# Altium schematic sheet [01] - POWER.SchDoc("[01] - POWER")
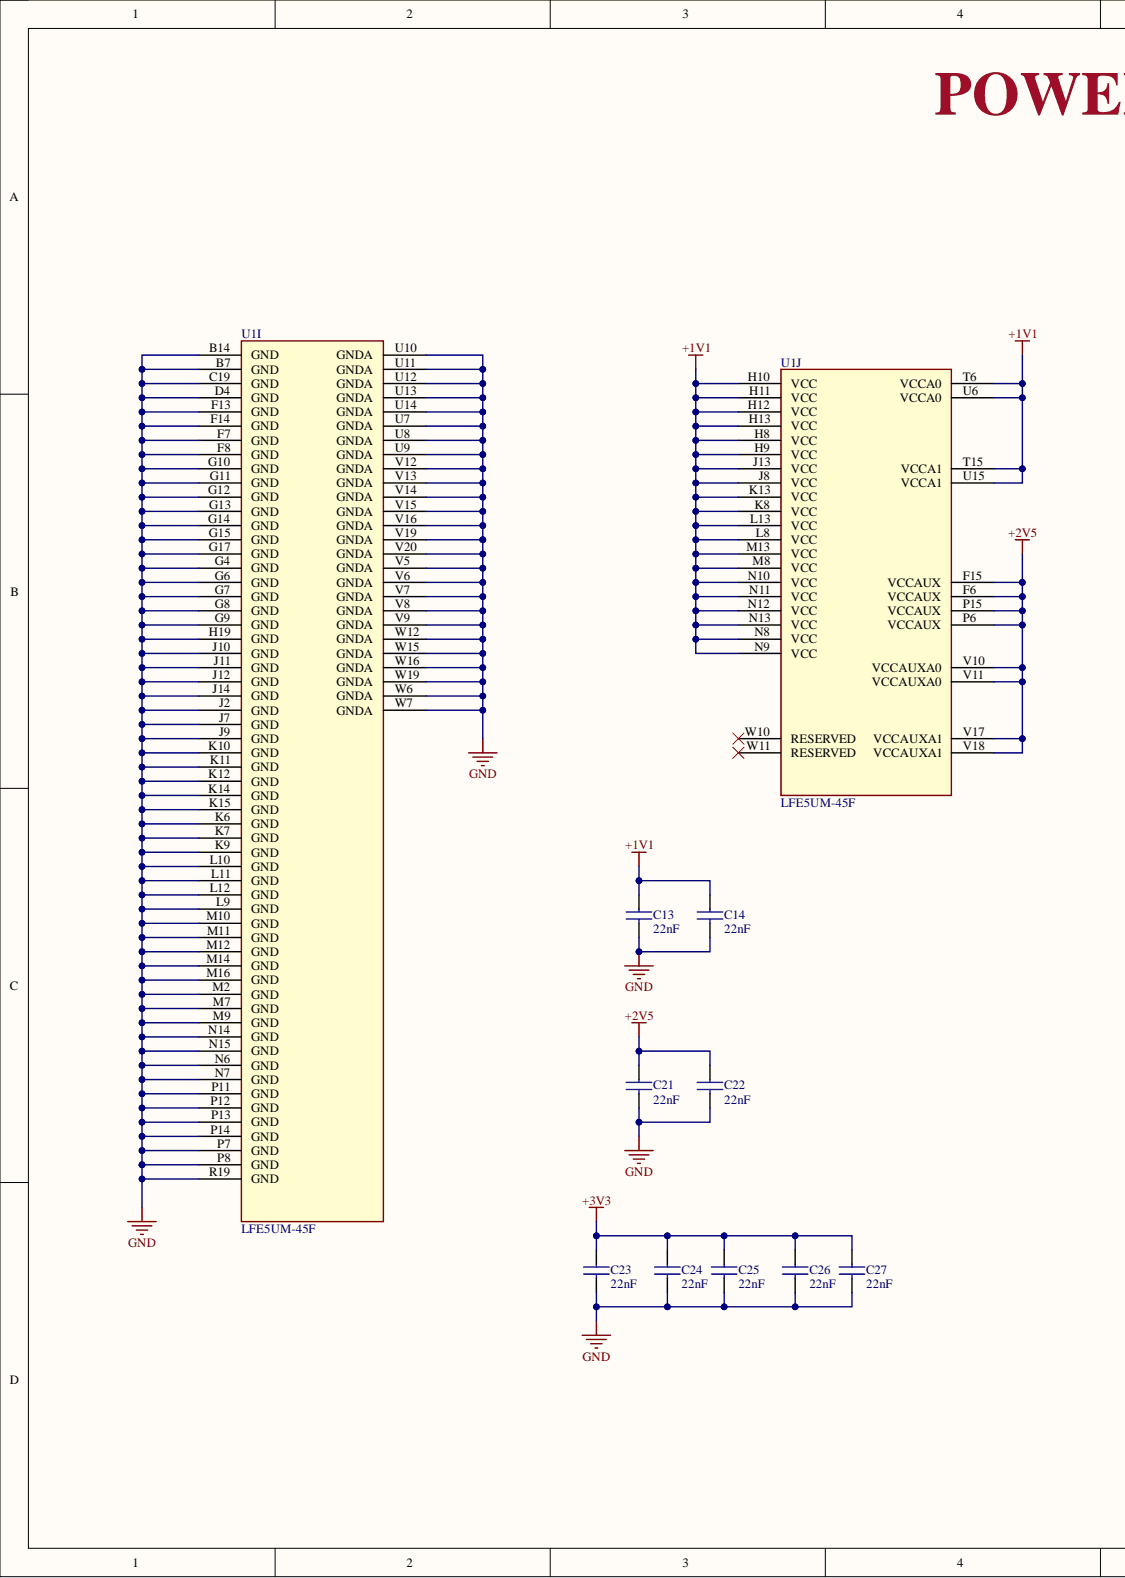
[diagram p0: [01] - POWER.SchDoc, left]
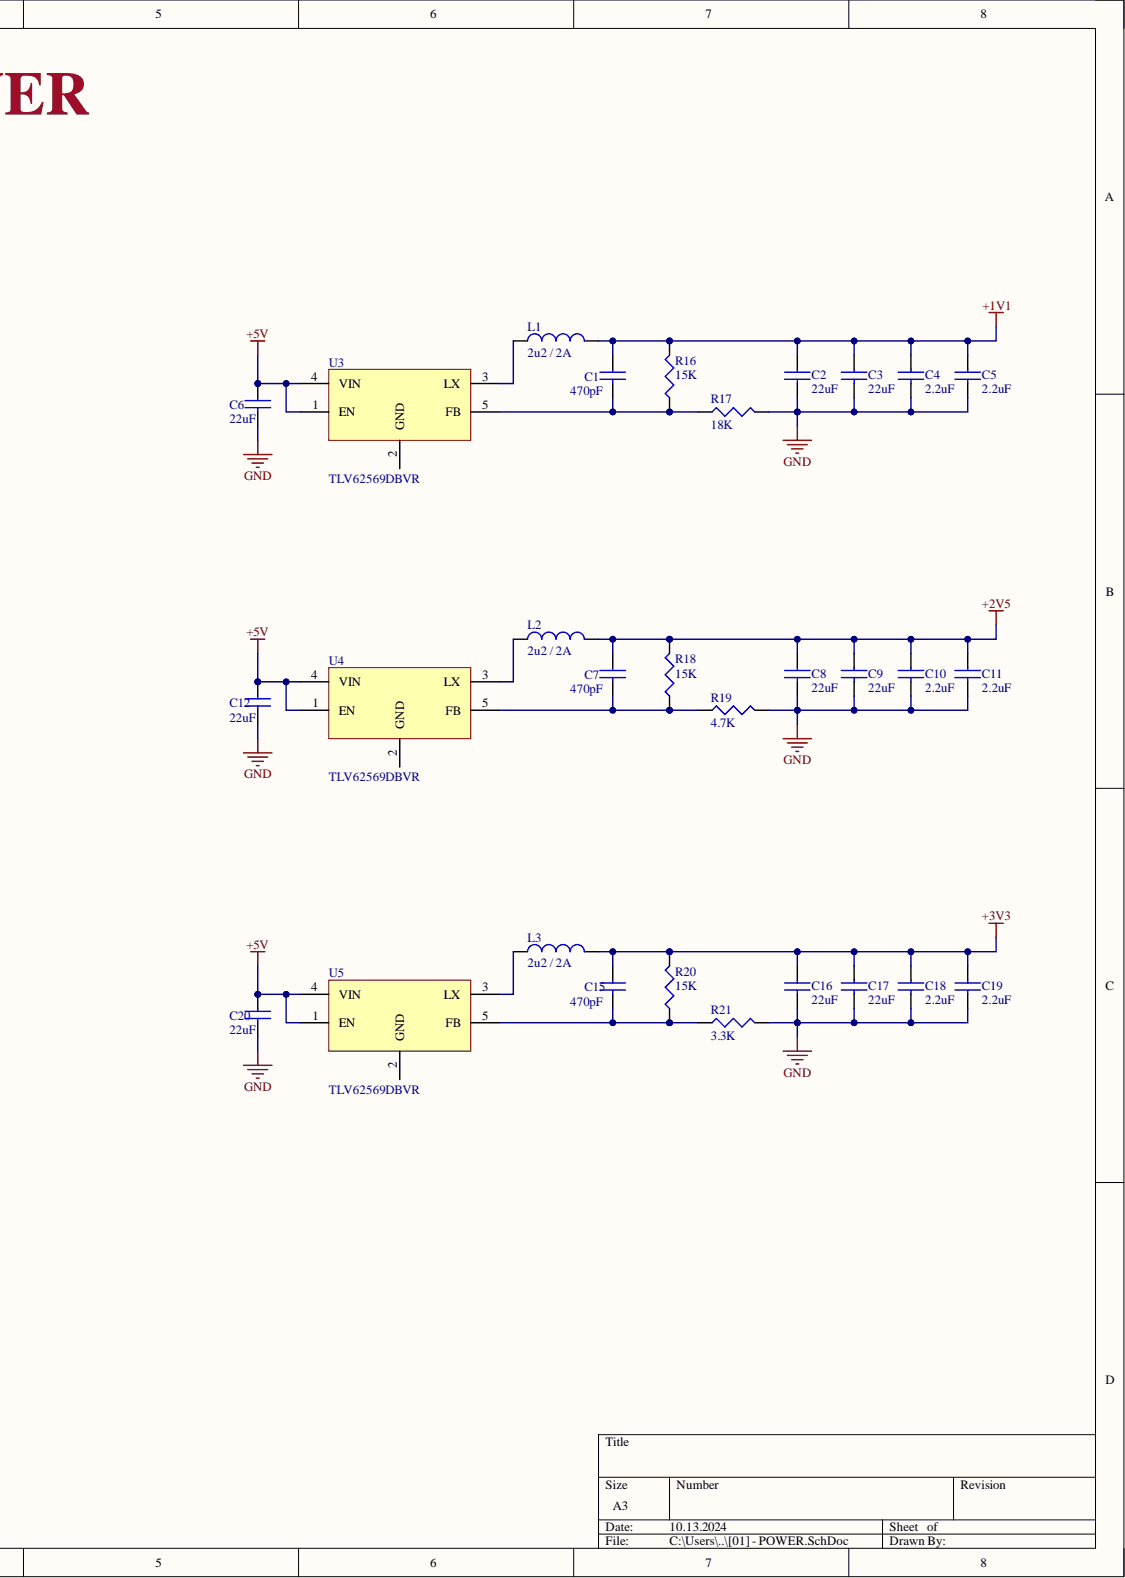
[diagram p1: [01] - POWER.SchDoc, right]

## Parts
C1  470pF  [C0603]
C2  22uF  [C0805]
C3  22uF  [C0805]
C4  2.2uF  [C0805]
C5  2.2uF  [C0805]
C6  22uF  [C0805]
C7  470pF  [C0603]
C8  22uF  [C0805]
C9  22uF  [C0805]
C10  2.2uF  [C0805]
C11  2.2uF  [C0805]
C12  22uF  [C0805]
C13  22nF  [C0603]
C14  22nF  [C0603]
C15  470pF  [C0603]
C16  22uF  [C0805]
C17  22uF  [C0805]
C18  2.2uF  [C0805]
C19  2.2uF  [C0805]
C20  22uF  [C0805]
C21  22nF  [C0603]
C22  22nF  [C0603]
C23  22nF  [C0603]
C24  22nF  [C0603]
C25  22nF  [C0603]
C26  22nF  [C0603]
C27  22nF  [C0603]
L1  2u2 / 2A  Current Rating: 2A  [C1210_IND]
L2  2u2 / 2A  Current Rating: 2A  [C1210_IND]
L3  2u2 / 2A  Current Rating: 2A  [C1210_IND]
R16  15K  [R0603]
R17  18K  [R0603]
R18  15K  [R0603]
R19  4.7K  [R0603]
R20  15K  [R0603]
R21  3.3K  [R0603]
U1  LFE5UM-45F  [LFE5BG381]  (parts I,J)
U3  TLV62569DBVR  [SOT95P370X145-5N]
U4  TLV62569DBVR  [SOT95P370X145-5N]
U5  TLV62569DBVR  [SOT95P370X145-5N]

## Nets
+1V1: C1.1 C2.1 C3.1 C4.1 C5.1 C13.1 C14.1 L1.2 R16.2 U1.H8(VCC) U1.H9(VCC) U1.H10(VCC) U1.H11(VCC) U1.H12(VCC) U1.H13(VCC) U1.J8(VCC) U1.J13(VCC) U1.K8(VCC) U1.K13(VCC) U1.L8(VCC) U1.L13(VCC) U1.M8(VCC) U1.M13(VCC) U1.N8(VCC) U1.N9(VCC) U1.N10(VCC) U1.N11(VCC) U1.N12(VCC) U1.N13(VCC) U1.T6(VCCA0) U1.T15(VCCA1) U1.U6(VCCA0) U1.U15(VCCA1)
+2V5: C7.1 C8.1 C9.1 C10.1 C11.1 C21.1 C22.1 L2.2 R18.2 U1.F6(VCCAUX) U1.F15(VCCAUX) U1.P6(VCCAUX) U1.P15(VCCAUX) U1.V10(VCCAUXA0) U1.V11(VCCAUXA0) U1.V17(VCCAUXA1) U1.V18(VCCAUXA1)
+3V3: C15.1 C16.1 C17.1 C18.1 C19.1 C23.1 C24.1 C25.1 C26.1 C27.1 L3.2 R20.2
+5V: C6.1 C12.1 C20.1 U3.1(EN) U3.4(VIN) U4.1(EN) U4.4(VIN) U5.1(EN) U5.4(VIN)
GND: C2.2 C3.2 C4.2 C5.2 C6.2 C8.2 C9.2 C10.2 C11.2 C12.2 C13.2 C14.2 C16.2 C17.2 C18.2 C19.2 C20.2 C21.2 C22.2 C23.2 C24.2 C25.2 C26.2 C27.2 R17.2 R19.2 R21.2 U1.B7(GND) U1.B14(GND) U1.C19(GND) U1.D4(GND) U1.F7(GND) U1.F8(GND) U1.F13(GND) U1.F14(GND) U1.G4(GND) U1.G6(GND) U1.G7(GND) U1.G8(GND) U1.G9(GND) U1.G10(GND) U1.G11(GND) U1.G12(GND) U1.G13(GND) U1.G14(GND) U1.G15(GND) U1.G17(GND) U1.H19(GND) U1.J2(GND) U1.J7(GND) U1.J9(GND) U1.J10(GND) U1.J11(GND) U1.J12(GND) U1.J14(GND) U1.K6(GND) U1.K7(GND) U1.K9(GND) U1.K10(GND) U1.K11(GND) U1.K12(GND) U1.K14(GND) U1.K15(GND) U1.L9(GND) U1.L10(GND) U1.L11(GND) U1.L12(GND) U1.M2(GND) U1.M7(GND) U1.M9(GND) U1.M10(GND) U1.M11(GND) U1.M12(GND) U1.M14(GND) U1.M16(GND) U1.N6(GND) U1.N7(GND) U1.N14(GND) U1.N15(GND) U1.P7(GND) U1.P8(GND) U1.P11(GND) U1.P12(GND) U1.P13(GND) U1.P14(GND) U1.R19(GND) U1.U7(GNDA) U1.U8(GNDA) U1.U9(GNDA) U1.U10(GNDA) U1.U11(GNDA) U1.U12(GNDA) U1.U13(GNDA) U1.U14(GNDA) U1.V5(GNDA) U1.V6(GNDA) U1.V7(GNDA) U1.V8(GNDA) U1.V9(GNDA) U1.V12(GNDA) U1.V13(GNDA) U1.V14(GNDA) U1.V15(GNDA) U1.V16(GNDA) U1.V19(GNDA) U1.V20(GNDA) U1.W6(GNDA) U1.W7(GNDA) U1.W12(GNDA) U1.W15(GNDA) U1.W16(GNDA) U1.W19(GNDA)
NetC1_2: C1.2 R16.1 R17.1 U3.5(FB)
NetC7_2: C7.2 R18.1 R19.1 U4.5(FB)
NetC15_2: C15.2 R20.1 R21.1 U5.5(FB)
NetL1_1: L1.1 U3.3(LX)
NetL2_1: L2.1 U4.3(LX)
NetL3_1: L3.1 U5.3(LX)

Not connected: U1 W10(RESERVED) W11(RESERVED); U3 2(GND); U4 2(GND); U5 2(GND)

## Library data of the parts
C1 C7 C15 = C0603C471K3RACTU: KEMET - C0603C471K3RACTU - SMD Multilayer Ceramic Capacitor, 470 pF, 25 V, 0603 [1608 Metric], ± 10%, X7R, C Series KEMET; Voltage Rating: 25V; Capacitance: 470pF; Case Code (Imperial): 0603; Case Code (Metric): 1608; Case/Package: 0603; Component Kind: Standard; ComponentLink1Description: http://www.farnell.com/datasheets/2064971.pdf?src-supplier=Element14; ComponentLink1URL: http://www.farnell.com/datasheets/2064971.pdf?src-supplier=Element14; ComponentLink2Description: http://www.farnell.com/datasheets/2746078.pdf?src-supplier=Farnell; ComponentLink2URL: http://www.farnell.com/datasheets/2746078.pdf?src-supplier=Farnell; ComponentLink3Description: https://api.kemet.com/component-edge/download/datasheet/C0603C471K3RACTU.pdf?src-supplier=Digi-Key; ComponentLink3URL: https://api.kemet.com/component-edge/download/datasheet/C0603C471K3RACTU.pdf?src-supplier=Digi-Key; ComponentLink4Description: http://components.arrow.com/part/dataview/2089229S9467247N7429?src-supplier=Arrow; ComponentLink4URL: http://components.arrow.com/part/dataview/2089229S9467247N7429?src-supplier=Arrow; ComponentLink5Description: http://www.mouser.com/ds/2/212/KEM_C1002_X7R_SMD-1102033.pdf?src-supplier=Mouser; ComponentLink5URL: http://www.mouser.com/ds/2/212/KEM_C1002_X7R_SMD-1102033.pdf?src-supplier=Mouser; ComponentLink6Description: http://www.farnell.com/datasheets/2746078.pdf?src-supplier=Newark; ComponentLink6URL: http://www.farnell.com/datasheets/2746078.pdf?src-supplier=Newark; ComponentLink7Description: http://components.arrow.com/part/dataview/0323_00323242?src-supplier=Arrow+Europe; ComponentLink7URL: http://components.arrow.com/part/dataview/0323_00323242?src-supplier=Arrow+Europe; Depth: 0.8mm; Dielectric: X7R; Dielectric Material: Ceramic; Height: 0.8mm; Lead Pitch: 0.7mm; Length: 1.6mm; Library Name: ECP5_V2.SchLib; Manufacturer: KEMET; Manufacturer Part Number: C0603C471K3RACTU; Max Operating Temperature: 125°C; Min Operating Temperature: -55°C; Mount: Surface Mount; Package Quantity: 4000; Packaging: Tape and Reel; Pricing 1: 1=0.1, 10=0.067, 100=0.0298, 500=0.02126, 1000=0.0167 (USD); Radiation Hardening: No; REACH SVHC: No SVHC; Stock 1: 28906; Supplier 1: Digi-Key; Supplier Part Number 1: 399-9086-6-ND; Termination: SMD/SMT; Thickness: 0.034inch; Tolerance: 10%; Voltage Rating (DC): 25V; Width: 0.031inch
C2 C3 C6 C8 C9 C12 C16 C17 C20 = CL21A226MQCLRNC: CAP CER 22UF 6.3V X5R 0805; Manufacturer: Samsung; Tolerance: 20%; Capacitance: 22uF; Case Code (Imperial): 0805; Case Code (Metric): 2012; Case/Package: 0805; Component Kind: Standard; ComponentLink1Description: http://www.samsungsem.com/kr/support/product-search/mlcc/CL21A226MQCLRNC.jsp?src-supplier=Digi-Key; ComponentLink1URL: http://www.samsungsem.com/kr/support/product-search/mlcc/CL21A226MQCLRNC.jsp?src-supplier=Digi-Key; Depth: 1.25mm; Dielectric: X5R; Height: 0.85mm; Lead Free: Lead Free; Length: 2mm; Library Name: ECP5_V2.SchLib; Manufacturer Part Number: CL21A226MQCLRNC; Max Operating Temperature: 85°C; Min Operating Temperature: -55°C; Mount: Surface Mount; Package Quantity: 1; Packaging: Tape and Reel; Pricing 1: 1=0.36, 10=0.247, 100=0.1484, 500=0.11132, 1000=0.09401 (USD); Radiation Hardening: No; Stock 1: 271195; Supplier 1: Digi-Key; Supplier Part Number 1: 1276-2413-6-ND; Thickness: 0.037inch; Voltage Rating: 6.3V; Width: 0.049inch
C4 C5 C10 C11 C18 C19 = CL21A225KAFNNNG: Ceramic capacitor SMD 0805 2.2 µF 25 V 10 %; Manufacturer: Samsung; Tolerance: 10%; Voltage Rating: 25V; Capacitance: 2.2uF; Case Code (Imperial): 0805; Case Code (Metric): 2012; Case/Package: 0805; Component Kind: Standard; ComponentLink1Description: http://www.samsungsem.com/global/support/library/product-catalog/__icsFiles/afieldfile/2014/11/13/MLCC.pdf?src-supplier=Digi-Key; ComponentLink1URL: http://www.samsungsem.com/global/support/library/product-catalog/__icsFiles/afieldfile/2014/11/13/MLCC.pdf?src-supplier=Digi-Key; ComponentLink2Description: https://produktinfo.conrad.com/datenblaetter/450000-474999/455579-da-01-en-VS_KONDENSATOR_0805.pdf?src-supplier=Conrad; ComponentLink2URL: https://produktinfo.conrad.com/datenblaetter/450000-474999/455579-da-01-en-VS_KONDENSATOR_0805.pdf?src-supplier=Conrad; Length: 0.079inch; Library Name: ECP5_V2.SchLib; Manufacturer Part Number: CL21A225KAFNNNG; Material: Ceramic; Max Operating Temperature: 85°C; Min Operating Temperature: -55°C; Mount: Surface Mount; Package Quantity: 3000; Packaging: Tape and Reel; Pricing 1: 1=0.15, 10=0.11, 100=0.0517, 500=0.03626, 1000=0.03002 (USD); Stock 1: 303381; Supplier 1: Digi-Key; Supplier Part Number 1: 1276-6458-6-ND; Thickness: 0.053inch; Width: 0.049inch
C13 C14 C21-C27 = CX0603MRX7R8BB223: Feed Through Capacitors 22nF X7R 20% 25V; Manufacturer: Yageo; Capacitance: 22nF; Case Code (Imperial): 0603; Case Code (Metric): 1608; Case/Package: 0603; Component Kind: Standard; ComponentLink1Description: http://www.yageo.com/NewPortal/_en/search/productDocs.jsp?YageoPartNumber=CX0603MRX7R8BB223&src-supplier=Digi-Key; ComponentLink1URL: http://www.yageo.com/NewPortal/_en/search/productDocs.jsp?YageoPartNumber=CX0603MRX7R8BB223&src-supplier=Digi-Key; Dielectric: X7R; Height: 0.65mm; Lead Free: Lead Free; Length: 0.063inch; Library Name: ECP5_V2.SchLib; Manufacturer Part Number: CX0603MRX7R8BB223; Max Operating Temperature: 125°C; Min Operating Temperature: -55°C; Mount: Surface Mount; Number of Pins: 2; Package Quantity: 1; Packaging: Tape and Reel; Pricing 1: 1=0.92, 10=0.676, 100=0.4636, 500=0.36702, 1000=0.30907 (USD); REACH SVHC: No SVHC; Stock 1: 11069; Supplier 1: Digi-Key; Supplier Part Number 1: 311-1234-6-ND; Termination: SMD/SMT; Thickness: 0.03inch; Tolerance: 20%; Voltage Rating: 25V; Voltage Rating (DC): 25V; Width: 0.034inch
L1-L3 = L1210R2R2MDWIT: FIXED IND 2.2UH 2A 80 MOHM SMD; Manufacturer: KEMET; Core Material: Ferrite; DC Current: 1.13A; DC Resistance (DCR): 80mR; Inductance Tolerance: 20%; Self Resonant Frequency: 190MHz; Shielding: Unshielded; Test Frequency: 100kHz; Case Code (Imperial): 1210; Case Code (Metric): 3225; Case/Package: 1210; Component Kind: Standard; ComponentLink1Description: https://content.kemet.com/datasheets/F3115.pdf?src-supplier=Mouser; ComponentLink1URL: https://content.kemet.com/datasheets/F3115.pdf?src-supplier=Mouser; ComponentLink2Description: https://content.kemet.com/datasheets/F3115.pdf?src-supplier=Avnet+Europe; ComponentLink2URL: https://content.kemet.com/datasheets/F3115.pdf?src-supplier=Avnet+Europe; ComponentLink3Description: http://www.farnell.com/datasheets/27262.pdf?src-supplier=Element14; ComponentLink3URL: http://www.farnell.com/datasheets/27262.pdf?src-supplier=Element14; ComponentLink4Description: https://api.kemet.com/component-edge/download/datasheet/L1210R2R2MDWIT.pdf?src-supplier=Digi-Key; ComponentLink4URL: https://api.kemet.com/component-edge/download/datasheet/L1210R2R2MDWIT.pdf?src-supplier=Digi-Key; ComponentLink5Description: http://www.kemet.com/Lists/ProductCatalog/Attachments/57/F3115.pdf?src-supplier=Avnet; ComponentLink5URL: http://www.kemet.com/Lists/ProductCatalog/Attachments/57/F3115.pdf?src-supplier=Avnet; Composition: Wirewound,Ceramic; Depth: 2.7mm; Height: 2.5mm; Height - Seated (Max): 0.106inch; Inductance: 2.2uH; Length: 0.126inch; Library Name: ECP5_V2.SchLib; Manufacturer Part Number: L1210R2R2MDWIT; Max DC Current: 2A; Max Operating Temperature: 105°C; Military Standard: Not; Min Operating Temperature: -40°C; Mount: Surface Mount; Package Quantity: 1000; Packaging: Tape and Reel; Pricing 1: 1=0.55, 10=0.479, 100=0.3421, 500=0.28738 (USD); Radiation Hardening: No; REACH SVHC: No SVHC; Stock 1: 2998; Supplier 1: Digi-Key; Supplier Part Number 1: 399-9598-6-ND; Termination: SMD/SMT; Tolerance: 20%; Width: 0.098inch
R16 R18 R20 = RC0603FR-0715KL: Res Thick Film 0603 15K Ohm 1% 1/10W ?100ppm/?C Molded SMD Paper T/R; Manufacturer: Yageo; Max Power Dissipation: 100mW; Resistance: 15kR; Temperature Coefficient: 100ppm/°C; Voltage Rating: 50V; Case Code (Imperial): 0603; Case Code (Metric): 1608; Case/Package: 0603; Component Kind: Standard; ComponentLink1Description: http://www.yageo.com/documents/recent/PYu-RC_Group_51_RoHS_L_10.pdf?src-supplier=Digi-Key; ComponentLink1URL: http://www.yageo.com/documents/recent/PYu-RC_Group_51_RoHS_L_10.pdf?src-supplier=Digi-Key; ComponentLink2Description: http://www.yageo.com/documents/recent/PYu-RC_Group_51_RoHS_L_9.pdf?src-supplier=Digi-Key; ComponentLink2URL: http://www.yageo.com/documents/recent/PYu-RC_Group_51_RoHS_L_9.pdf?src-supplier=Digi-Key; ComponentLink3Description: https://www.verical.com/server-webapp/html/getDatasheet?partID=327895&src-supplier=Verical; ComponentLink3URL: https://www.verical.com/server-webapp/html/getDatasheet?partID=327895&src-supplier=Verical; Composition: Thick Film; Depth: 0.8mm; Features: Moisture Resistant; Height: 0.022inch; Lead Free: Lead Free; Length: 1.6mm; Library Name: ECP5_V2.SchLib; Manufacturer Part Number: RC0603FR-0715KL; Max Operating Temperature: 155°C; Military Standard: Not; Min Operating Temperature: -55°C; Number of Pins: 2; Number of Terminations: 2; Package Quantity: 1; Packaging: Tape and Reel; Power Rating: 100mW; Pricing 1: 1=0.1, 10=0.023, 100=0.0094, 1000=0.00421, 2500=0.00366 (USD); REACH SVHC: Unknown; Stock 1: 3342455; Supplier 1: Digi-Key; Supplier Part Number 1: 311-15.0KHRCT-ND; Termination: SMD/SMT; Tolerance: 1%; Voltage Rating (DC): 50V; Width: 0.031inch
R17 = RC0603FR-0718KL: YAGEO (PHYCOMP) - RC0603FR-0718KL - SMD Chip Resistor, 0603 [1608 Metric], 18 kohm, RC Series, 75 V, Thick Film, 100 mW; Power Rating: 100mW; Resistance: 18kR; Temperature Coefficient: 100ppm/°C; Tolerance: 1%; Voltage Rating: 75V; Voltage Rating (DC): 50V; Case Code (Imperial): 0603; Case Code (Metric): 1608; Case/Package: 0603; Component Kind: Standard; ComponentLink1Description: http://documents.rs-components.com/techlib://itc_doclist_GB.htm?stockno=5049959&src-supplier=RSComponents; ComponentLink1URL: http://documents.rs-components.com/techlib://itc_doclist_GB.htm?stockno=5049959&src-supplier=RSComponents; ComponentLink2Description: https://www.verical.com/server-webapp/html/getDatasheet?partID=325162&src-supplier=Verical; ComponentLink2URL: https://www.verical.com/server-webapp/html/getDatasheet?partID=325162&src-supplier=Verical; ComponentLink3Description: http://www.farnell.com/datasheets/2167052.pdf?src-supplier=Element14; ComponentLink3URL: http://www.farnell.com/datasheets/2167052.pdf?src-supplier=Element14; ComponentLink4Description: http://www.farnell.com/datasheets/1868787.pdf?src-supplier=Element14; ComponentLink4URL: http://www.farnell.com/datasheets/1868787.pdf?src-supplier=Element14; Composition: Thick Film; Depth: 0.8mm; Height: 0.45mm; Lead Free: Lead Free; Length: 1.6mm; Library Name: ECP5_V2.SchLib; Manufacturer: Yageo; Manufacturer Part Number: RC0603FR-0718KL; Max Operating Temperature: 155°C; Military Standard: Not; Min Operating Temperature: -55°C; Number of Pins: 2; Packaging: Tape and Reel; Pricing 1: 1=0.1, 10=0.023, 100=0.0094, 1000=0.00421, 2500=0.00366 (USD); REACH SVHC: Unknown; Stock 1: 0; Supplier 1: Digi-Key; Supplier Part Number 1: 311-18.0KHRDKR-ND; Termination: SMD/SMT; Weight: 7.1E-05oz; Width: 0.8mm
R19 = RC0603FR-074K7L: RES SMD 4.7K OHM 1% 1/10W 0603; Manufacturer: Yageo; Power Rating: 100mW; Resistance: 4.7kR; Temperature Coefficient: 100ppm/°C; Voltage Rating: 50V; Case Code (Imperial): 0603; Case Code (Metric): 1608; Case/Package: 0603; Component Kind: Standard; ComponentLink1Description: https://www.verical.com/server-webapp/html/getDatasheet?partID=422754&src-supplier=Verical; ComponentLink1URL: https://www.verical.com/server-webapp/html/getDatasheet?partID=422754&src-supplier=Verical; ComponentLink2Description: http://www.farnell.com/datasheets/2167052.pdf?src-supplier=Element14; ComponentLink2URL: http://www.farnell.com/datasheets/2167052.pdf?src-supplier=Element14; ComponentLink3Description: http://www.farnell.com/datasheets/38590.pdf?src-supplier=Element14; ComponentLink3URL: http://www.farnell.com/datasheets/38590.pdf?src-supplier=Element14; ComponentLink4Description: http://www.farnell.com/datasheets/1868787.pdf?src-supplier=Element14; ComponentLink4URL: http://www.farnell.com/datasheets/1868787.pdf?src-supplier=Element14; Composition: Thick Film; Depth: 0.8mm; Height: 0.45mm; Lead Free: Lead Free; Lead Pitch: 0.3mm; Length: 1.6mm; Library Name: ECP5_V2.SchLib; Manufacturer Part Number: RC0603FR-074K7L; Max Operating Temperature: 155°C; Military Standard: Not; Min Operating Temperature: -55°C; Number of Pins: 2; Packaging: Tape and Reel; Pricing 1: 1=0.1, 10=0.023, 100=0.0094, 1000=0.00421, 2500=0.00366 (USD); REACH SVHC: No SVHC; Stock 1: 1446473; Supplier 1: Digi-Key; Supplier Part Number 1: 311-4.70KHRCT-ND; Termination: SMD/SMT; Tolerance: 1%; Voltage Rating (DC): 50V; Width: 0.8mm
R21 = RC0603FR-073K3L: YAGEO - RC0603FR-073K3L - SMD Chip Resistor, 0603 [1608 Metric], 3.3 kohm, RC Series, 75 V, Thick Film, 100 mW; Max Power Dissipation: 100mW; Resistance: 3.3kR; Temperature Coefficient: 100ppm/°C; Tolerance: 1%; Voltage Rating: 50V; Case Code (Imperial): 0603; Case Code (Metric): 1608; Case/Package: 0603; Component Kind: Standard; ComponentLink1Description: http://www.yageo.com/documents/recent/PYu-RC_Group_51_RoHS_L_10.pdf?src-supplier=Digi-Key; ComponentLink1URL: http://www.yageo.com/documents/recent/PYu-RC_Group_51_RoHS_L_10.pdf?src-supplier=Digi-Key; ComponentLink2Description: http://www.farnell.com/datasheets/2167052.pdf?src-supplier=Element14; ComponentLink2URL: http://www.farnell.com/datasheets/2167052.pdf?src-supplier=Element14; ComponentLink3Description: http://www.farnell.com/datasheets/1686128.pdf?src-supplier=Element14; ComponentLink3URL: http://www.farnell.com/datasheets/1686128.pdf?src-supplier=Element14; ComponentLink4Description: http://www.farnell.com/datasheets/1868787.pdf?src-supplier=Element14; ComponentLink4URL: http://www.farnell.com/datasheets/1868787.pdf?src-supplier=Element14; Composition: Thick Film; Depth: 0.8mm; Features: Moisture Resistant; Height: 0.45mm; Lead Free: Lead Free; Length: 1.6mm; Library Name: ECP5_V2.SchLib; Manufacturer: Yageo; Manufacturer Part Number: RC0603FR-073K3L; Max Operating Temperature: 155°C; Military Standard: Not; Min Operating Temperature: -55°C; Number of Pins: 2; Number of Terminations: 2; Package Quantity: 1; Packaging: Tape and Reel; Power Rating: 100mW; Pricing 1: 1=0.1, 10=0.023, 100=0.0094, 1000=0.00421, 2500=0.00366 (USD); REACH SVHC: No SVHC; Stock 1: 1337597; Supplier 1: Digi-Key; Supplier Part Number 1: 311-3.30KHRDKR-ND; Termination: SMD/SMT; Voltage Rating (DC): 50V; Width: 0.8mm
U1 = LFE5UM-45F-6BG381C: ECP5 Series 44 K LUTs 203 I/O 1.1 V Slow Surface Mount FPGA - CABGA-381; Manufacturer: Lattice Semiconductor; Number of I/Os: 203; Number of Logic Blocks (LABs): 11000; Number of Logic Elements/Cells: 44000; RAM Size: 1990656b; Case/Package: FBGA; Component Kind: Standard; ComponentLink1Description: http://www.latticesemi.com/~/media/LatticeSemi/Documents/DataSheets/ECP5/DS1044.pdf?src-supplier=Digi-Key; ComponentLink1URL: http://www.latticesemi.com/~/media/LatticeSemi/Documents/DataSheets/ECP5/DS1044.pdf?src-supplier=Digi-Key; Lead Free: Lead Free; Library Name: ECP5_V2.SchLib; Manufacturer Part Number: LFE5UM-45F-6BG381C; Max Operating Temperature: 85°C; Min Operating Temperature: 0°C; Mount: Surface Mount; Pricing 1: 90=19.13967 (USD); Stock 1: 0; Supplier 1: Digi-Key; Supplier Part Number 1: 220-1994-ND
U3-U5 = TLV62569DBVR: 1-A HIGH EFFICIENCY STEP-DOWN; Manufacturer: Texas Instruments; Max Input Voltage: 5.5V; Min Input Voltage: 2.5V; Min Output Voltage: 600mV; Output Configuration: Positive; Output Current: 2A; Output Type: Adjustable; Switching Frequency: 1.5MHz; Synchronous Rectifier: Yes; Case/Package: SOT-23-5; Component Kind: Standard; ComponentLink1Description: http://www.ti.com/lit/ds/symlink/tlv62569.pdf?src-supplier=Farnell; ComponentLink1URL: http://www.ti.com/lit/ds/symlink/tlv62569.pdf?src-supplier=Farnell; ComponentLink2Description: http://www.ti.com/lit/ds/symlink/tlv62569.pdf?src-supplier=Digi-Key; ComponentLink2URL: http://www.ti.com/lit/ds/symlink/tlv62569.pdf?src-supplier=Digi-Key; Library Name: ECP5_V2.SchLib; Manufacturer Part Number: TLV62569DBVR; Max Operating Temperature: 125°C; Max Output Voltage: 5.5V; Min Operating Temperature: -40°C; Mount: Surface Mount; Packaging: Cut Tape; Pricing 1: 1=0.53, 10=0.454, 25=0.4236, 100=0.3146, 250=0.2662, 500=0.2541, 1000=0.18755 (USD); Stock 1: 2680; Supplier 1: Digi-Key; Supplier Part Number 1: 296-47360-6-ND
